# Altium schematic sheet main_miniusb.SchDoc(main_miniusb)
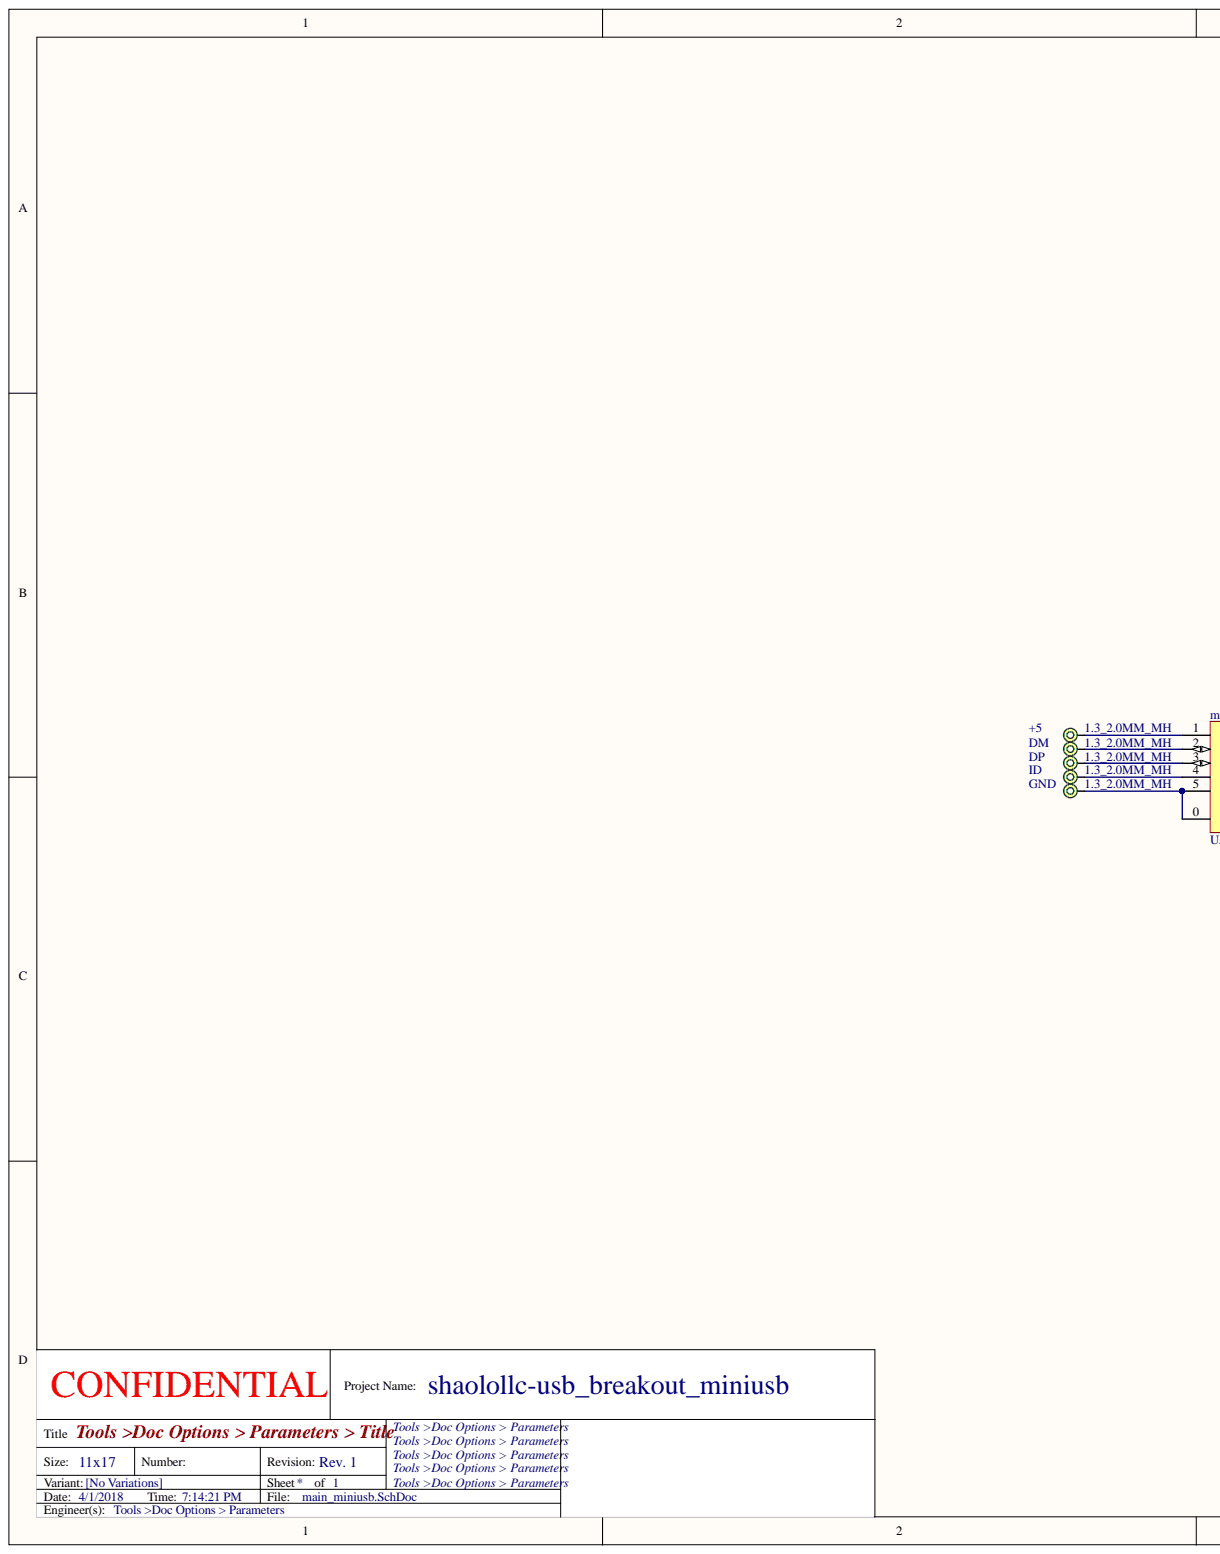
[diagram p0: main_miniusb.SchDoc, left]
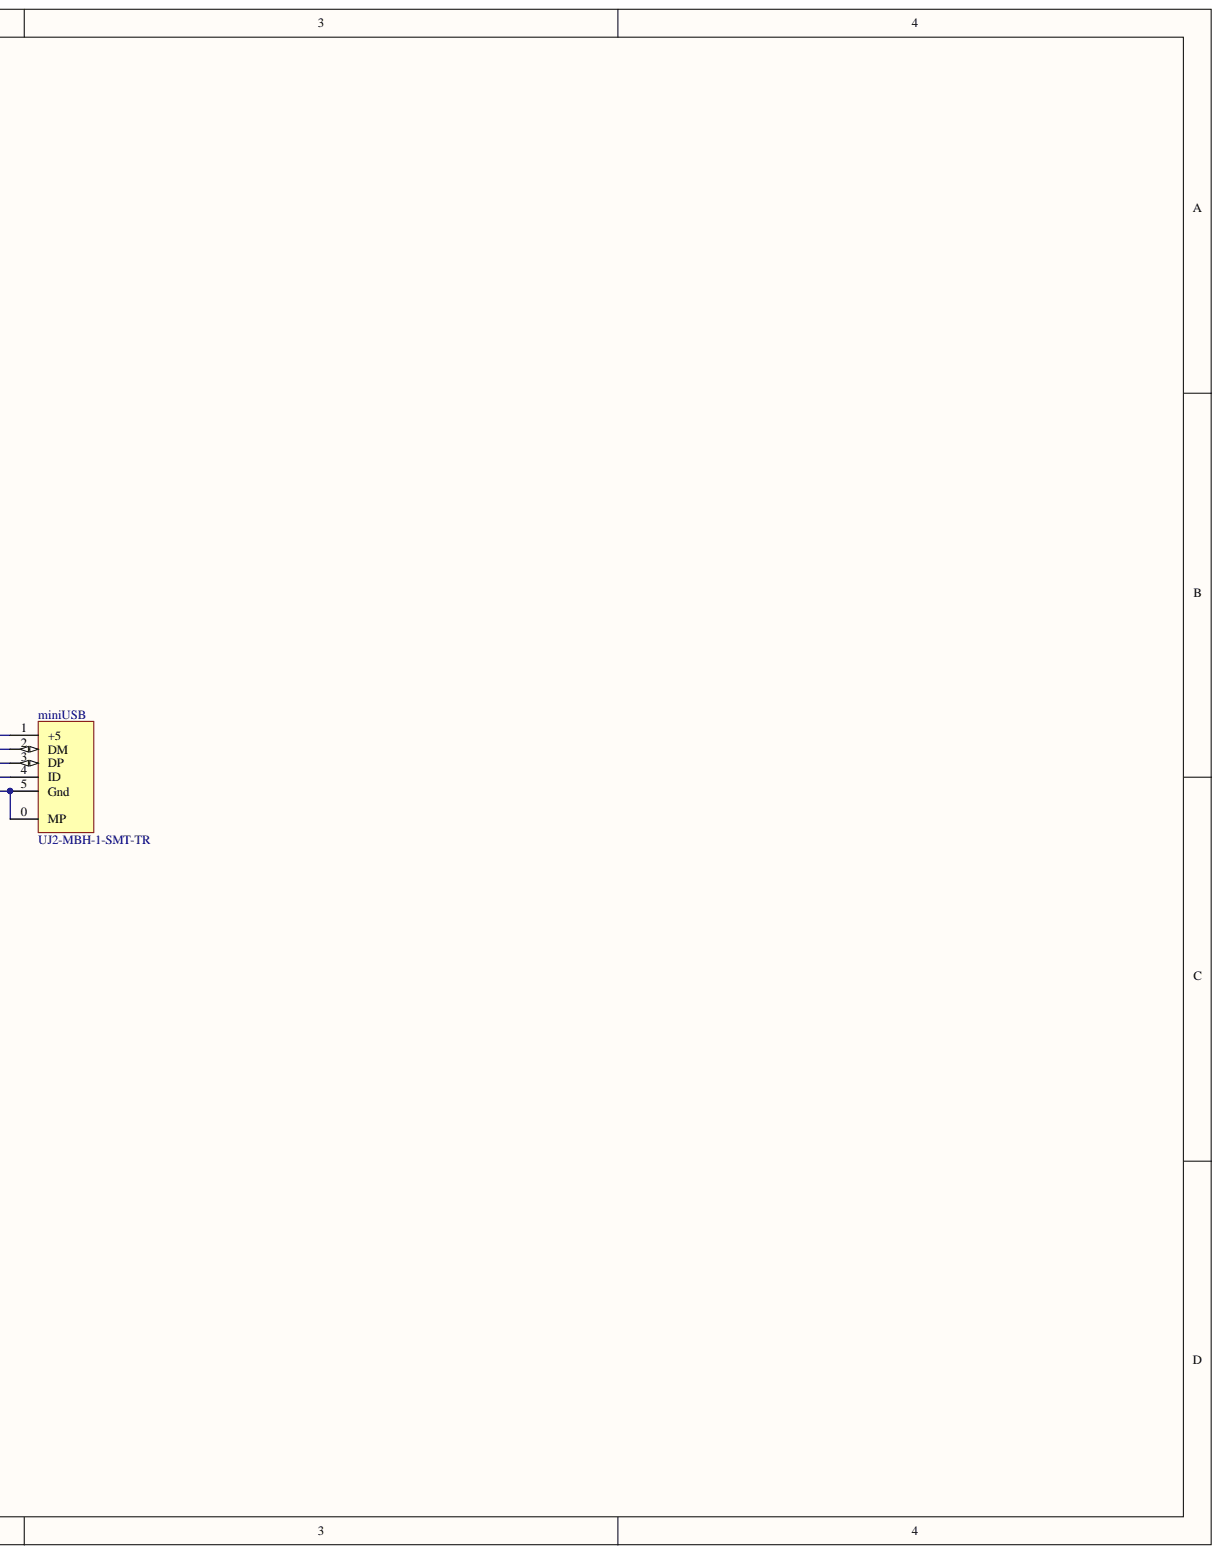
[diagram p1: main_miniusb.SchDoc, right]

## Parts
+5  value 1.3_2.0MM_MH  [1.3_2.0MM_PH]  (no BOM)
DM  value 1.3_2.0MM_MH  [1.3_2.0MM_PH]  (no BOM)
DP  value 1.3_2.0MM_MH  [1.3_2.0MM_PH]  (no BOM)
GND  value 1.3_2.0MM_MH  [1.3_2.0MM_PH]  (no BOM)
ID  value 1.3_2.0MM_MH  [1.3_2.0MM_PH]  (no BOM)
miniUSB  value UJ2-MBH-1-SMT-TR  [USB MINI B W PEGS - UJ2]

## Nets
Net+5_1: +5.1 miniUSB.1(+5)
NetDM_1: DM.1 miniUSB.2(DM)
NetDP_1: DP.1 miniUSB.3(DP)
NetGND_1: GND.1 miniUSB.0(MP) miniUSB.5(Gnd)
NetID_1: ID.1 miniUSB.4(ID)

## Library data of the parts
+5 DM DP GND ID = PCB_0092: 1.3_2.0MM_MH; Manufacturer Part Number: S46; Manufacturer: Misc PCB; Reviewer: shawn@shaolo.com; Database Table Name: MISC_PCB; UID: 61; Supplier 1: ShaoloLLC; RoHS: True; Published: 1/20/2015; Part Number: PCB_0092; ComponentLink3Description: Package URL; ComponentLink2Description: Datasheet URL; ComponentLink1URL: 0; ComponentLink1Description: Manufacturer URL; Comment: =Comment; ClassName: Plated Holes; Publisher: shawn@shaolo.com; Library Name: Altium Access.DbLib
miniUSB = CONN_0247: USB JACK 2.0, MINI B TYPE, 5 PIN; Manufacturer: Cui; SMT?: True; Reviewer: shawn@shaolo.com; Gender?: Female; Database Table Name: EMECH_Connector; Conductors: 5; UID: 246; Supplier Part Number 1: 102-4003-1-ND; Supplier 1: Digi-Key; RoHS: True; Reflow?: True; Published: 4/1/2018; Part Number: CONN_0247; Orientation: RA; Manufacturer Part Number: UJ2-MBH-1-SMT-TR; HelpURL: https://www.cui.com/product/resource/digikeypdf/uj2-mbh-smt.pdf; ComponentLink3Description: Package URL; ComponentLink2Description: Datasheet URL; ComponentLink1URL: 0; ComponentLink1Description: Manufacturer URL; Component Kind: Standard; Comment: =Comment; Publisher: shawn@shaolo.com; Library Name: Altium Access.DbLib
